# Altium schematic sheet Battery Management.SchDoc
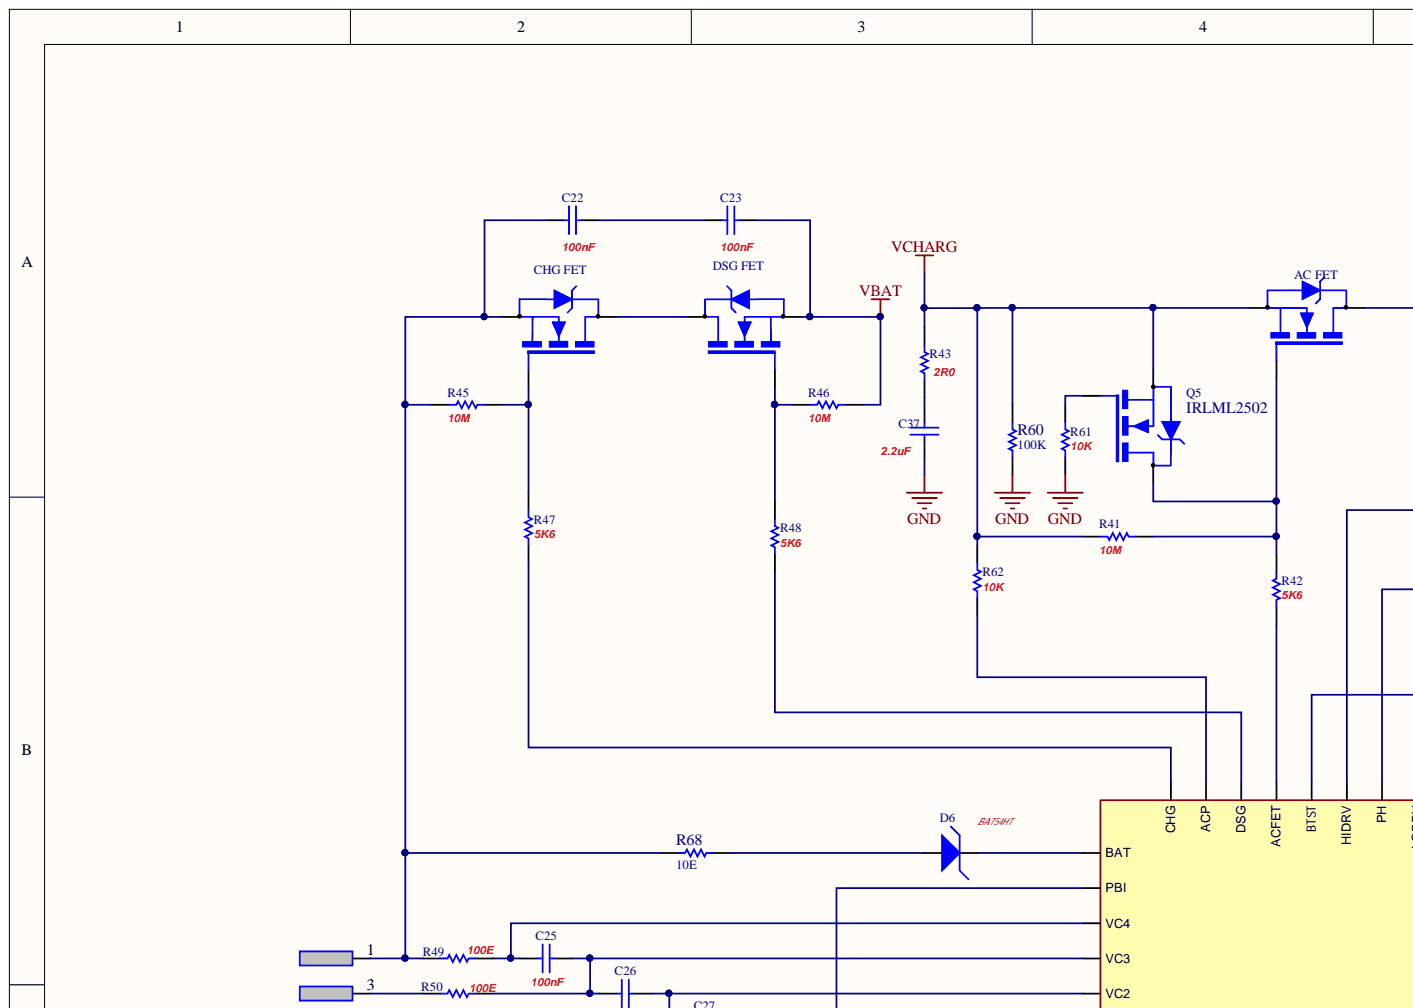
[diagram p0: Battery Management.SchDoc, top left]
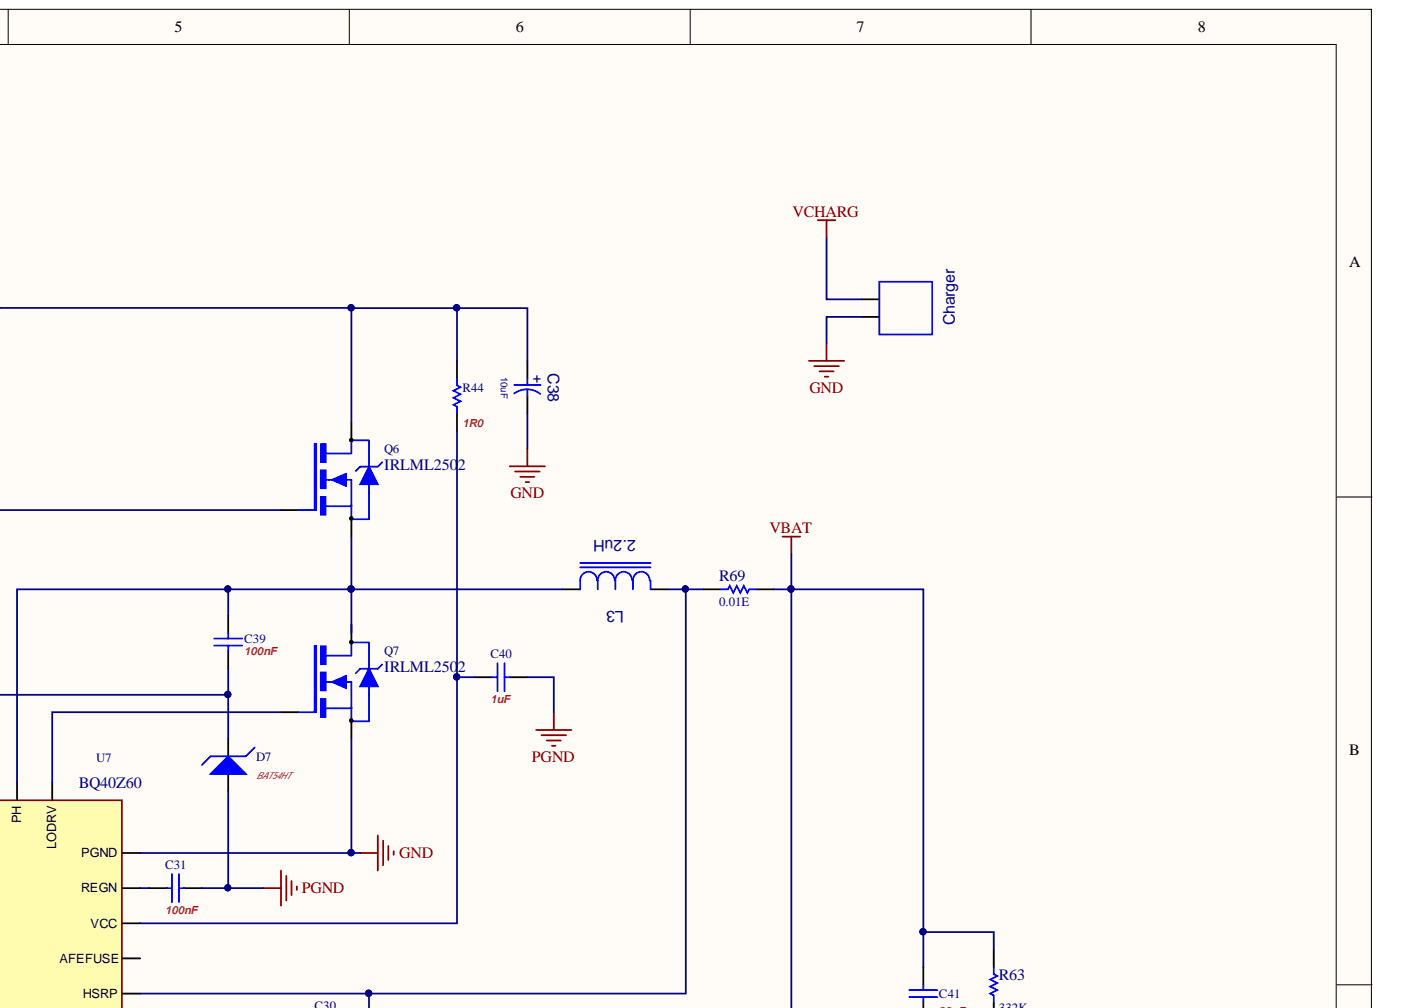
[diagram p1: Battery Management.SchDoc, top right]
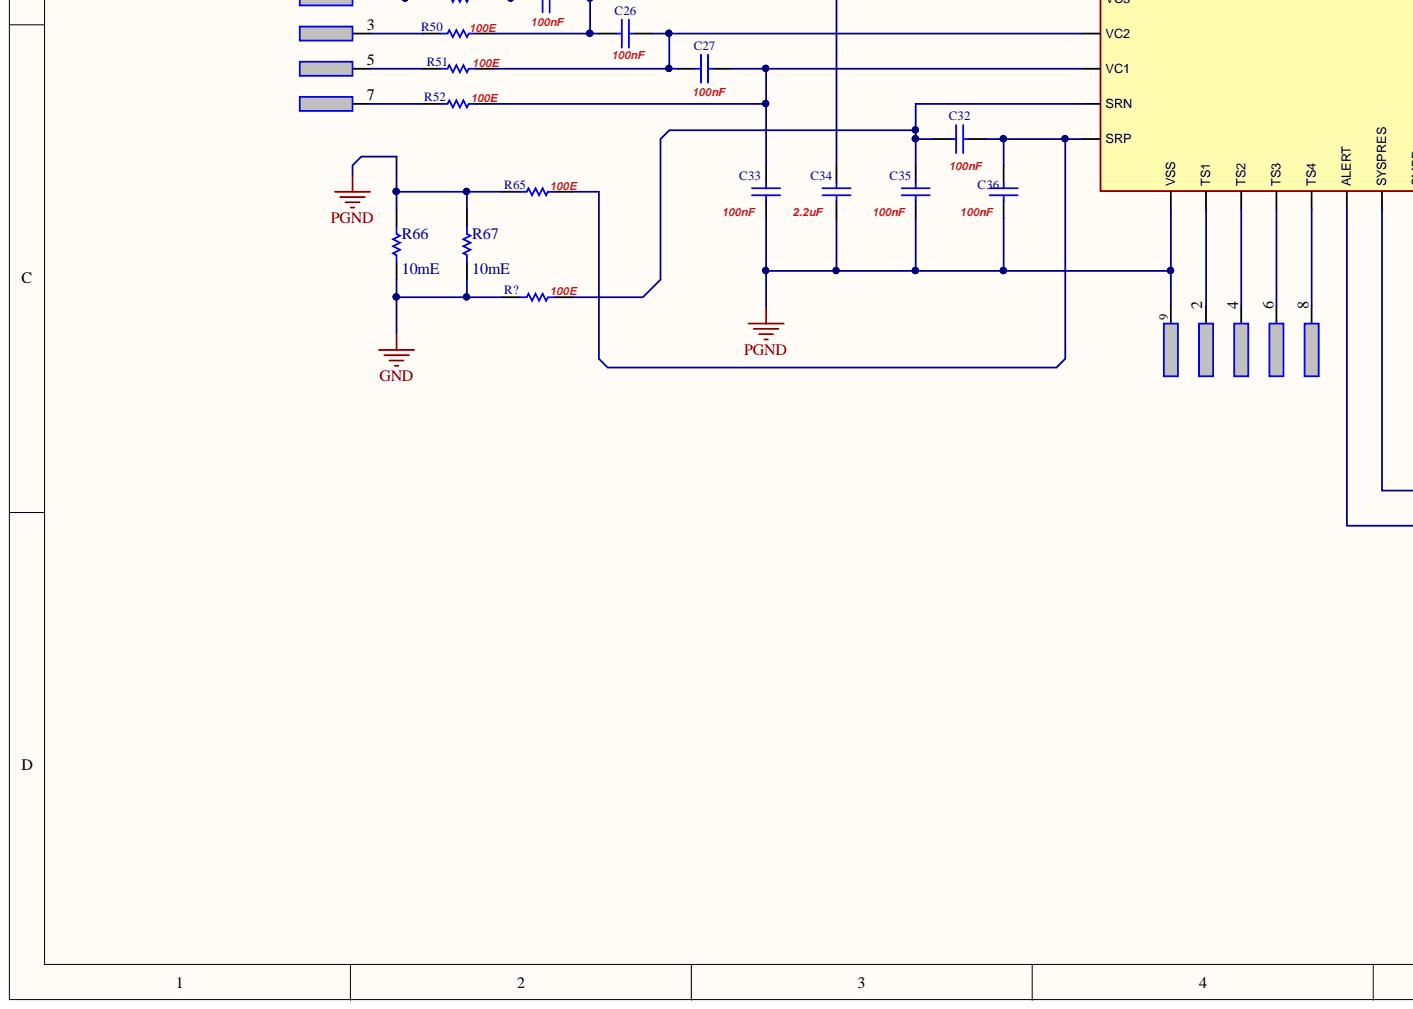
[diagram p2: Battery Management.SchDoc, bottom left]
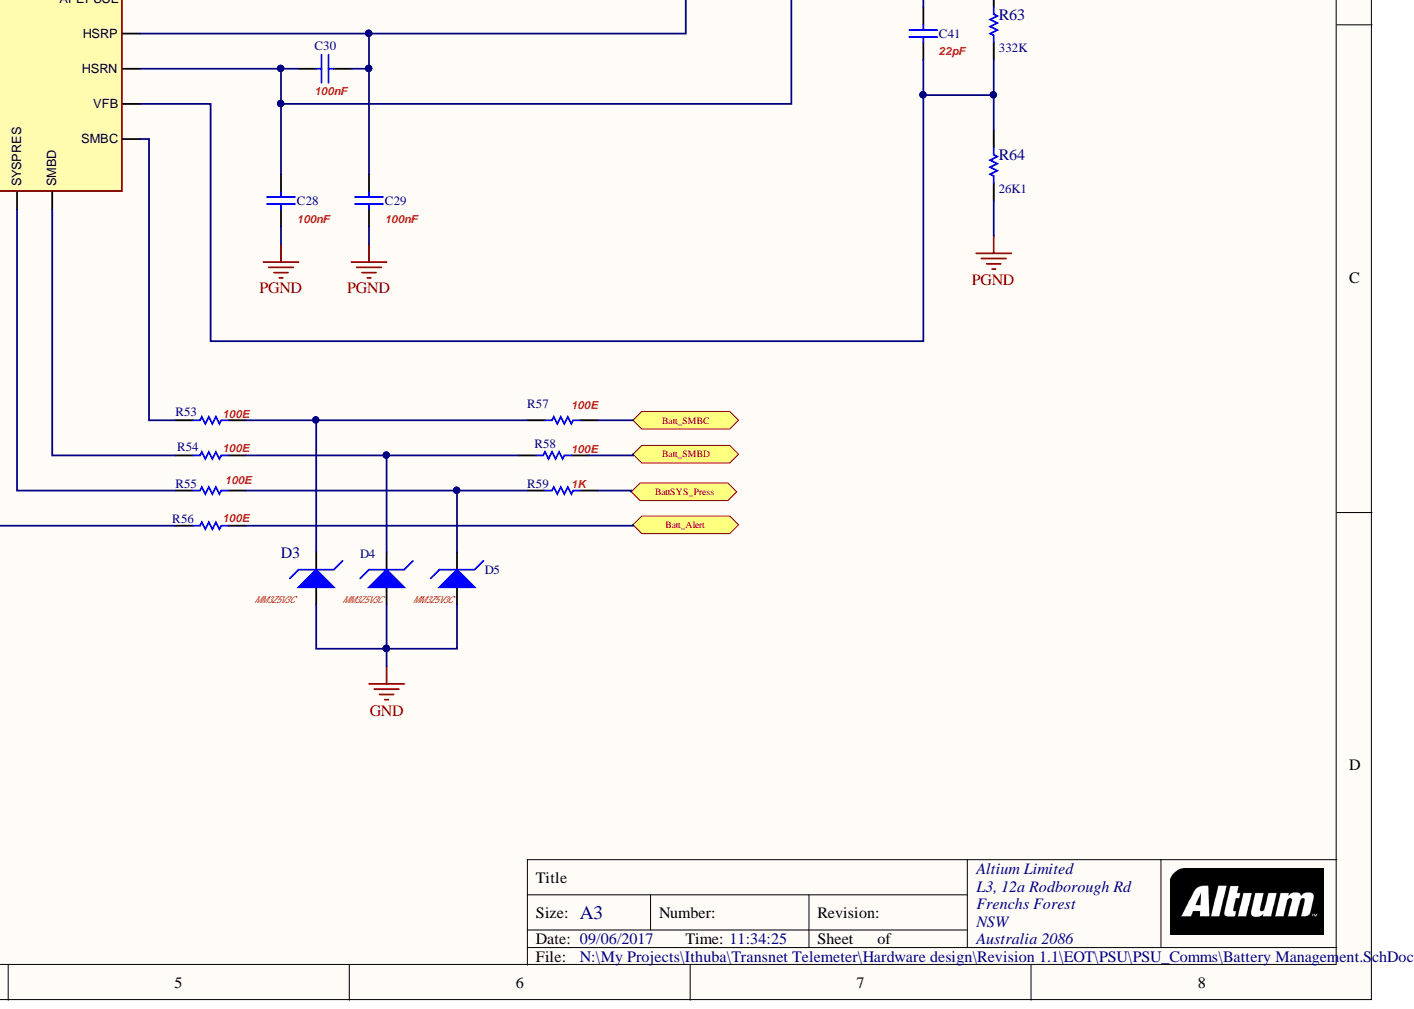
[diagram p3: Battery Management.SchDoc, bottom right]

## Parts
AC_FET  NTD4909NT4G  [DPAK]  (value not drawn)
BAT  IDC9  [HDR1X9 -Frict]  (value not drawn)  (parts A,B,C,D,E,F,G,H,I)
C22  value 100nF  [CAP0603]  (hidden comment: Cap 0805)
C23  value 100nF  [CAP0603]  (hidden comment: Cap 0805)
C25  value 100nF  [CAP0603]  (hidden comment: Cap 0805)
C26  value 100nF  [CAP0603]  (hidden comment: Cap 0805)
C27  value 100nF  [CAP0603]  (hidden comment: Cap 0805)
C28  value 100nF  [CAP0603]  (hidden comment: Cap 0805)
C29  value 100nF  [CAP0603]  (hidden comment: Cap 0805)
C30  value 100nF  [CAP0603]  (hidden comment: Cap 0805)
C31  value 100nF  [CAP0603]  (hidden comment: Cap 0805)
C32  value 100nF  [CAP0603]  (hidden comment: Cap 0805)
C33  value 100nF  [CAP0603]  (hidden comment: Cap 0805)
C34  value 2.2uF  [C-0805]  (hidden comment: Cap 0805)
C35  value 100nF  [CAP0603]  (hidden comment: Cap 0805)
C36  value 100nF  [CAP0603]  (hidden comment: Cap 0805)
C37  value 2.2uF  [C-0805]  (hidden comment: Cap 0805)
C38  value 10uF  [C-TANT#A]  (hidden comment: Cap Tantalum TH)
C39  value 100nF  [CAP0603]  (hidden comment: Cap 0805)
C40  value 1uF  [C-0805]  (hidden comment: Cap 0603)
C41  value 22pF  [CAP0603]  (hidden comment: Cap 0805)
Charger  LOAD  [PWR2_H]  (value not drawn)
CHG_FET  NTD4909NT4G  [DPAK]  (value not drawn)
D3  MM3Z5V3C  [SOD323]
D4  MM3Z5V3C  [SOD323]
D5  MM3Z5V3C  [SOD323]
D6  BAT54HT  [SOD123F]
D7  BAT54HT  [SOD123F]
DSG_FET  NTD4909NT4G  [DPAK]  (value not drawn)
L3  value 2.2uH  [IHLP2020]  (hidden comment: INDUCTOR-SMT_IHLP2020)
Q5  IRLML2502  [SOT-23]
Q6  IRLML2502  [SOT-23]
Q7  IRLML2502  [SOT-23]
R41  value 10M  [R-0603]  (hidden comment: Resistor - SMD 0805)
R42  value 5K6  [R-0603]  (hidden comment: Resistor - SMD 0805)
R43  value 2R0  [R1206]  (hidden comment: Resistor - SMD 1206)
R44  value 1R0  [R1206]  (hidden comment: Resistor - SMD 1206)
R45  value 10M  [R-0603]  (hidden comment: Resistor - SMD 0805)
R46  value 10M  [R-0603]  (hidden comment: Resistor - SMD 0805)
R47  value 5K6  [R-0603]  (hidden comment: Resistor - SMD 0805)
R48  value 5K6  [R-0603]  (hidden comment: Resistor - SMD 0805)
R49  value 100E  [R-0603]  (hidden comment: Resistor - SMD 0805)
R50  value 100E  [R-0603]  (hidden comment: Resistor - SMD 0805)
R51  value 100E  [R-0603]  (hidden comment: Resistor - SMD 0805)
R52  value 100E  [R-0603]  (hidden comment: Resistor - SMD 0805)
R53  value 100E  [R-0603]  (hidden comment: Resistor - SMD 0805)
R54  value 100E  [R-0603]  (hidden comment: Resistor - SMD 0805)
R55  value 100E  [R-0603]  (hidden comment: Resistor - SMD 0805)
R56  value 100E  [R-0603]  (hidden comment: Resistor - SMD 0805)
R57  value 100E  [R-0603]  (hidden comment: Resistor - SMD 0805)
R58  value 100E  [R-0603]  (hidden comment: Resistor - SMD 0805)
R59  value 1K  [R-0603]  (hidden comment: Resistor - SMD 0805)
R60  value 100K  [R-0603]  (hidden comment: Resistor - SMD 0805)
R61  value 10K  [R-0603]  (hidden comment: Resistor - SMD 0805)
R62  value 10K  [R-0603]  (hidden comment: Resistor - SMD 0805)
R63  value 332K  [R-0603]  (hidden comment: Resistor - SMD 0805)
R64  value 26K1  [R-0603]  (hidden comment: Resistor - SMD 0805)
R65  value 100E  [R-0603]  (hidden comment: Resistor - SMD 0805)
R66  value 10mE  [R1206]  (hidden comment: Resistor - SMD 1206)
R67  value 10mE  [R1206]  (hidden comment: Resistor - SMD 1206)
R68  value 10E  [R-0603]  (hidden comment: Resistor - SMD 0805)
R69  value 0.01E  [R-0603]  (hidden comment: Resistor - SMD 2512)
R?  value 100E  [R-0603]  (hidden comment: Resistor - SMD 0805)
U7  BQ40Z60  [ti-VQFN32]

## Ports
Batt_Alert, Batt_SMBC, Batt_SMBD, BattSYS_Press

## Nets
GND: C37.2 C38.MINUS Charger.2 D3.A D4.A D5.A Q7.2 R60.1 R61.1 R66.1 R67.1 R?.1 U7.24(PGND)
PGND: BAT.9 C28.1 C29.1 C31.2 C33.2 C34.2 C35.2 C36.2 C40.2 D7.A R64.1 R65.1 R66.2 R67.2 U7.9(VSS)
VBAT: C23.1 C28.2 C30.1 C41.2 DSG_FET.2 R46.2 R63.2 R69.2 U7.19(HSRN)
VCHARG: AC_FET.2 Charger.1 Q5.2 R41.2 R43.2 R60.2 R62.2
Batt_Alert: R56.1 ; port Batt_Alert
Batt_SMBC: R57.1 ; port Batt_SMBC
Batt_SMBD: R58.1 ; port Batt_SMBD
BattSYS_Press: R59.1 ; port BattSYS_Press
NetAC FET_1: AC_FET.1 Q5.3 R41.1 R42.2
NetAC FET_3: AC_FET.3 C38.PLUS Q6.3 R44.2
NetBAT_1: BAT.1 C22.2 CHG_FET.2 R45.1 R49.2 R68.1
NetBAT_2: BAT.2 U7.10(TS1)
NetBAT_3: BAT.3 R50.2
NetBAT_4: BAT.4 U7.11(TS2)
NetBAT_5: BAT.5 R51.2
NetBAT_6: BAT.6 U7.12(TS3)
NetBAT_7: BAT.7 R52.1
NetBAT_8: BAT.8 U7.13(TS4)
NetC22_1: C22.1 C23.2
NetC25_1: C25.1 C26.2 R50.1 U7.4(VC3)
NetC25_2: C25.2 R49.1 U7.3(VC4)
NetC26_1: C26.1 C27.2 R51.1 U7.5(VC2)
NetC27_1: C27.1 C33.1 R52.2 U7.6(VC1)
NetC29_2: C29.2 C30.2 L3.1 R69.1 U7.20(HSRP)
NetC31_1: C31.1 U7.23(REGN)
NetC32_1: C32.1 C36.1 R65.2 U7.8(SRP)
NetC32_2: C32.2 C35.1 R?.2 U7.7(SRN)
NetC34_1: C34.1 U7.2(PBI)
NetC37_1: C37.1 R43.1
NetC39_1: C39.1 D7.K U7.28(BTST)
NetC39_2: C39.2 L3.2 Q6.2 U7.26(PH)
NetC40_1: C40.1 R44.1 U7.22(VCC)
NetC41_1: C41.1 R63.1 R64.2 U7.18(VFB)
NetCHG FET_1: CHG_FET.1 R45.2 R47.2
NetCHG FET_3: CHG_FET.3 DSG_FET.3
NetD3_K: D3.K R53.1 R57.2
NetD4_K: D4.K R54.1 R58.2
NetD5_K: D5.K R55.1 R59.2
NetD6_A: D6.A R68.2
NetD6_K: D6.K U7.1(BAT)
NetDSG FET_1: DSG_FET.1 R46.1 R48.2
NetQ5_1: Q5.1 R61.2
NetQ6_1: Q6.1 U7.27(HIDRV)
NetQ7_1: Q7.1 U7.25(LODRV)
NetR42_1: R42.1 U7.29(ACFET)
NetR47_1: R47.1 U7.32(CHG)
NetR48_1: R48.1 U7.30(DSG)
NetR53_2: R53.2 U7.17(SMBC)
NetR54_2: R54.2 U7.16(SMBD)
NetR55_2: R55.2 U7.15(SYSPRES)
NetR56_2: R56.2 U7.14(ALERT)
NetR62_1: R62.1 U7.31(ACP)

Not connected: Q7 3; U7 21(AFEFUSE)

## Library data of the parts
BAT = CON9M_SIL_Friction: amtec 9Ways  Male Connector Straight Friction; Component Kind: Standard; Library Name: MKM Connectors.IntLib
C22 C23 C25-C37 C39-C41 = Cap 0805: Capacitor SMD; Component Kind: Standard; LatestRevisionDate: 17-Jul-2002; LatestRevisionNote: Re-released for DXP Platform.; PackageReference: 0805; Published: 8-Jun-2000; Library Name: MKM Capacitors.IntLib; Publisher: Altium Limited
C38 = C-TANT#A: Tantalum Capacitor SMD; Component Kind: Standard; Library Name: MKM Capacitors.IntLib
D3-D5 = MM3Z5V3: Zener Diode; Code_JEDEC: DO-214-AA; Component Kind: Standard; LatestRevisionDate: 17-Jul-2002; LatestRevisionNote: Re-released for DXP Platform.; PackageReference: SMB; Published: 8-Jun-2000; Signal Integrity: D Schottky; Library Name: MKM Diodes.IntLib; Publisher: Altium Limited
D6 D7 = BAT54H: Zener Diode; Code_JEDEC: DO-214-AA; Component Kind: Standard; LatestRevisionDate: 17-Jul-2002; LatestRevisionNote: Re-released for DXP Platform.; PackageReference: SMB; Published: 8-Jun-2000; Signal Integrity: D Schottky; Library Name: MKM Diodes.IntLib; Publisher: Altium Limited
L3 = INDUCTOR-SMT_IHLP2020: INDUCTOR-100uH square; Manufacturer: Vishay; Component Kind: Standard; Supplier: RS components; Library Name: MKM Inductance_transformers.IntLib
Q5-Q7 = IRLML2803: HEXFET Power MOSFET; Component Kind: Standard; ComponentLink1Description: Manufacturer Link; ComponentLink1URL: http://www.irf.com/; ComponentLink2Description: Datasheet; ComponentLink2URL: http://www.irf.com/product-info/datasheets/data/irlml2803.pdf; DatasheetVersion: Aug-1997; LatestRevisionDate: 20-Nov-2009; LatestRevisionNote: IPC-7351 Footprint Added.; PackageDescription: 3-Pin SOT-23 Package 0.95 mm Pitch, 2.3 mm Lead Span; PackageReference: SOT-23; Published: 8-Jun-2000; Simulation: IRLML2803; Library Name: MKM Transistors.IntLib; Publisher: Altium Limited
R41 R45 R46 = R0805- 1M: Resistor - SMD 0603; Component Kind: Standard; LatestRevisionDate: 17-Jul-2002; LatestRevisionNote: Re-released for DXP Platform.; PackageReference: AXIAL-0.3; Published: 8-Jun-2000; Signal Integrity: Res; Simulation: RESISTOR; Library Name: MKM Resistors.IntLib; Publisher: Altium Limited
R42 R47 R48 = R0805- 5K6: Resistor - SMD 0603; Component Kind: Standard; LatestRevisionDate: 17-Jul-2002; LatestRevisionNote: Re-released for DXP Platform.; PackageReference: AXIAL-0.3; Published: 8-Jun-2000; Signal Integrity: Res; Simulation: RESISTOR; Library Name: MKM Resistors.IntLib; Publisher: Altium Limited
R43 R44 R66 R67 = R-1206: Component Kind: Standard; Description: Resistor - SMD 1206; LatestRevisionDate: 17-Jul-2002; LatestRevisionNote: Re-released for DXP Platform.; PackageReference: AXIAL-0.3; PCB3D: smt_resisto1206; Published: 8-Jun-2000; Signal Integrity: Res; Simulation: RESISTOR; Library Name: MKM Resistors.IntLib; Publisher: Altium Limited
R49-R58 R65 R? = R0805- 100E: Resistor - SMD 0603; Component Kind: Standard; LatestRevisionDate: 17-Jul-2002; LatestRevisionNote: Re-released for DXP Platform.; PackageReference: AXIAL-0.3; Published: 8-Jun-2000; Signal Integrity: Res; Simulation: RESISTOR; Library Name: MKM Resistors.IntLib; Publisher: Altium Limited
R59 = R0805- 1K: Resistor - SMD 0603; Component Kind: Standard; LatestRevisionDate: 17-Jul-2002; LatestRevisionNote: Re-released for DXP Platform.; PackageReference: AXIAL-0.3; Published: 8-Jun-2000; Signal Integrity: Res; Simulation: RESISTOR; Library Name: MKM Resistors.IntLib; Publisher: Altium Limited
R60 = R0805- 100K: Resistor - SMD 0603; Component Kind: Standard; LatestRevisionDate: 17-Jul-2002; LatestRevisionNote: Re-released for DXP Platform.; PackageReference: AXIAL-0.3; Published: 8-Jun-2000; Signal Integrity: Res; Simulation: RESISTOR; Library Name: MKM Resistors.IntLib; Publisher: Altium Limited
R61 R62 = R0805- 10K: Resistor - SMD 0603; Component Kind: Standard; LatestRevisionDate: 17-Jul-2002; LatestRevisionNote: Re-released for DXP Platform.; PackageReference: AXIAL-0.3; Published: 8-Jun-2000; Signal Integrity: Res; Simulation: RESISTOR; Library Name: MKM Resistors.IntLib; Publisher: Altium Limited
R63 = R0805- 330K: Resistor - SMD 0603; Component Kind: Standard; LatestRevisionDate: 17-Jul-2002; LatestRevisionNote: Re-released for DXP Platform.; PackageReference: AXIAL-0.3; Published: 8-Jun-2000; Signal Integrity: Res; Simulation: RESISTOR; Library Name: MKM Resistors.IntLib; Publisher: Altium Limited
R64 = R0805- 22E: Resistor - SMD 0603; Component Kind: Standard; LatestRevisionDate: 17-Jul-2002; LatestRevisionNote: Re-released for DXP Platform.; PackageReference: AXIAL-0.3; Published: 8-Jun-2000; Signal Integrity: Res; Simulation: RESISTOR; Library Name: MKM Resistors.IntLib; Publisher: Altium Limited
R68 = R0805- 10E: Resistor - SMD 0603; Component Kind: Standard; LatestRevisionDate: 17-Jul-2002; LatestRevisionNote: Re-released for DXP Platform.; PackageReference: AXIAL-0.3; Published: 8-Jun-2000; Signal Integrity: Res; Simulation: RESISTOR; Library Name: MKM Resistors.IntLib; Publisher: Altium Limited
R69 = R2512- 10mE: Resistor - SMD 0603; Component Kind: Standard; LatestRevisionDate: 17-Jul-2002; LatestRevisionNote: Re-released for DXP Platform.; PackageReference: AXIAL-0.3; Published: 8-Jun-2000; Signal Integrity: Res; Simulation: RESISTOR; Library Name: MKM Resistors.IntLib; Publisher: Altium Limited
U7 = BQ40Z60: Programmable Battery Pack Manager; Component Kind: Standard; PartNumber: BQ40Z60; Value: BQ40Z60; Library Name: MKM Tech ICs.IntLib
AC_FET CHG_FET DSG_FET = NTD4909NT4G: Component Kind: Standard; Description: NTD4909NT4G; Library Name: MKM Transistors.IntLib
Charger = LOAD: Component Kind: Standard; Description: LOAD; LatestRevisionDate: 17-Jul-2002; LatestRevisionNote: Re-released for DXP Platform.; Library Name: MKM Connectors.IntLib; Publisher: Altium Limited
